# Altium schematic sheet MotorController.SchDoc
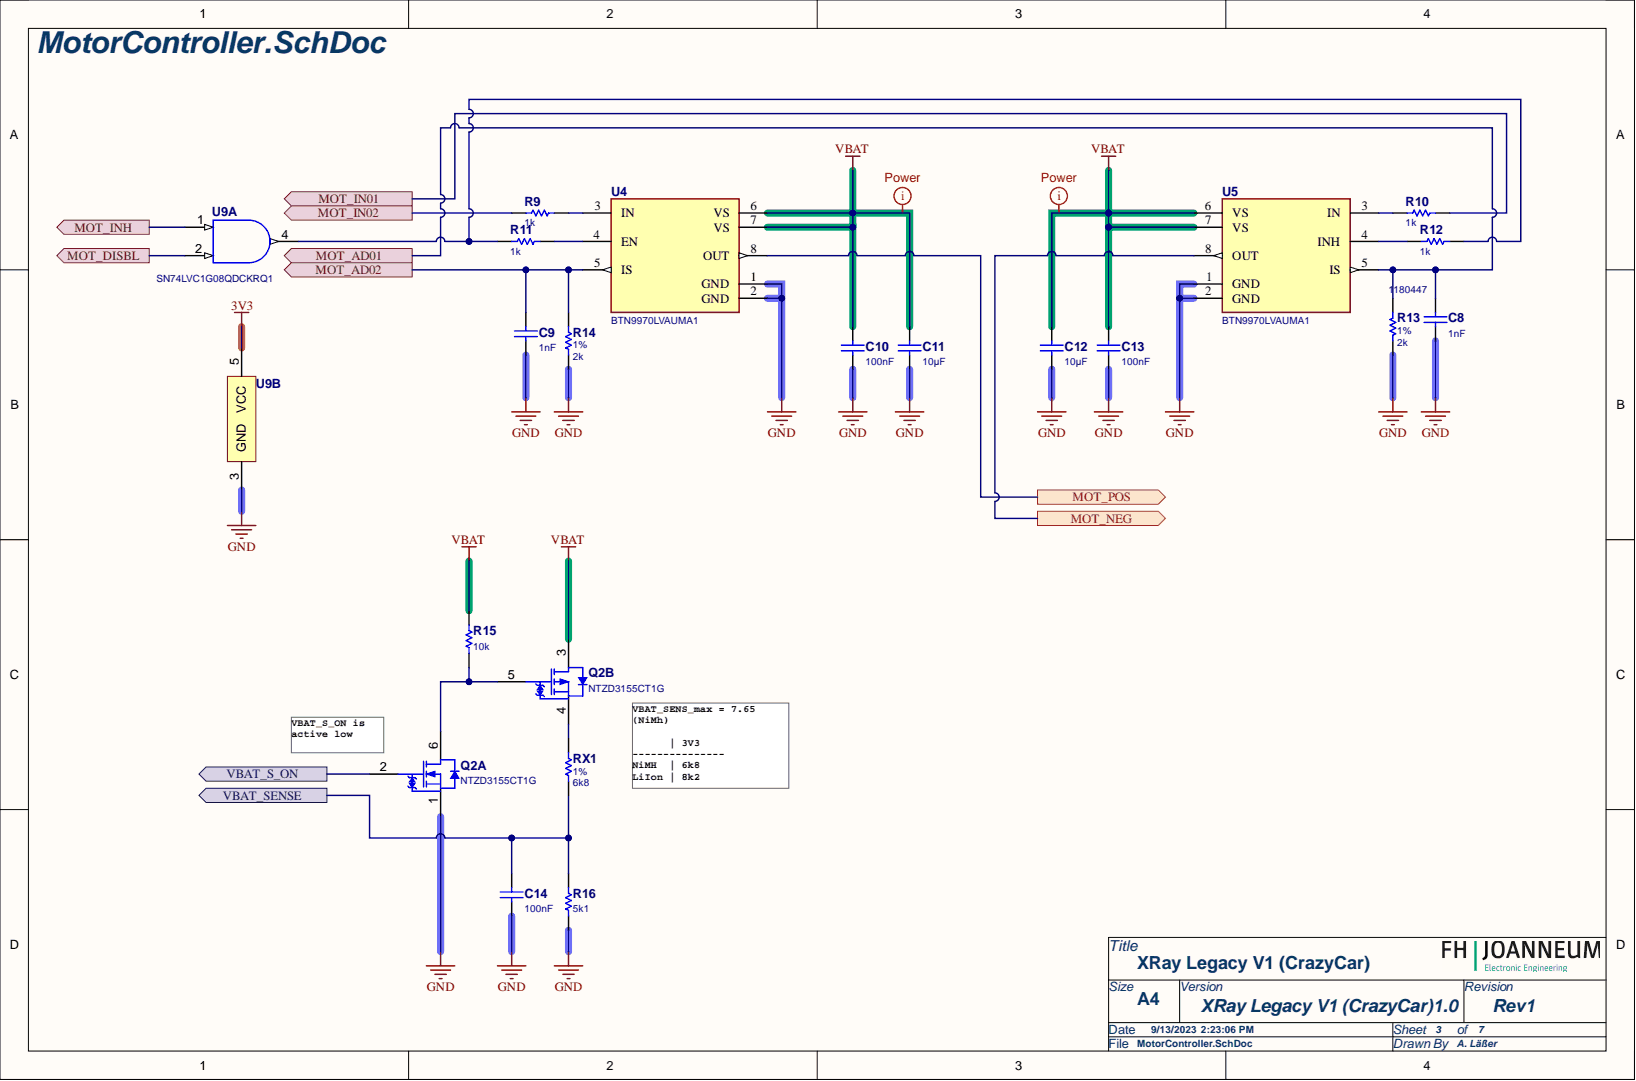
[diagram p0: MotorController.SchDoc]

## Parts
C8  1nF  [WCAP-CSGP_0805_L2W1.25H0.8_Reflow]
C9  1nF  [WCAP-CSGP_0805_L2W1.25H0.8_Reflow]
C10  100nF  [WCAP-CSGP_0805_L2W1.25H0.8_Reflow]
C11  10µF  [WCAP-CSGP_0805_L2W1.25H1.25]
C12  10µF  [WCAP-CSGP_0805_L2W1.25H1.25]
C13  100nF  [WCAP-CSGP_0805_L2W1.25H0.8_Reflow]
C14  100nF  [WCAP-CSGP_0805_L2W1.25H0.8_Reflow]
Q2  NTZD3155CT1G  [ONSC-SOT-563-6-463A-01_V]  (parts A,B)
R9  Resistance: 1k  [RESC2013X60X35ML10T20]  (hidden comment: RC0805FR-071KL)
R10  Resistance: 1k  [RESC2013X60X35ML10T20]  (hidden comment: RC0805FR-071KL)
R11  Resistance: 1k  [RESC2013X60X35ML10T20]  (hidden comment: RC0805FR-071KL)
R12  Resistance: 1k  [RESC2013X60X35ML10T20]  (hidden comment: RC0805FR-071KL)
R13  Resistance: 2k  Supplier Part Number 2: 1180447  Tolerance: 1%  [RESC2013X60X35ML10T20]  (hidden comment: RC0805FR-072K0L)
R14  Resistance: 2k  Tolerance: 1%  [RESC2013X60X35ML10T20]  (hidden comment: RC0805FR-072K0L)
R15  Resistance: 10k  [RESC2013X60X35ML10T20]  (hidden comment: RC0805FR-0710KL)
R16  Resistance: 5k1  [RESC2013X60X35ML10T20]  (hidden comment: RC0805FR-0710KL)
RX1  Resistance: 6k8  Tolerance: 1%  [RESC2013X60X35LL10T20]  (hidden comment: RC0805FR-136K8L)
U4  BTN9970LVAUMA1  [FP-PG-HSOF-7-MFG]
U5  BTN9970LVAUMA1  [FP-PG-HSOF-7-MFG]
U9  SN74LVC1G08QDCKRQ1  [DCK0005A_M]  (parts A,B)

## Ports
MOT_AD01, MOT_AD02, MOT_DISBL, MOT_IN01, MOT_IN02, MOT_INH, MOT_NEG, MOT_POS, VBAT_S_ON, VBAT_SENSE

## Nets
3V3: U9.5(VCC)
GND: C8.2 C9.2 C10.1 C11.2 C12.2 C13.1 C14.1 Q2.1 R13.2 R14.2 R16.2 U4.1(GND) U4.2(GND) U5.1(GND) U5.2(GND) U9.3(GND)
VBAT: C10.2 C11.1 C12.1 C13.2 Q2.3 R15.1 U4.6(VS) U4.7(VS) U5.6(VS) U5.7(VS)
MOT_AD01: C8.1 R13.1 U5.5(IS) ; port MOT_AD01
MOT_AD02: C9.1 R14.1 U4.5(IS) ; port MOT_AD02
MOT_DISBL: U9.2 ; port MOT_DISBL
MOT_IN01: R10.2 ; port MOT_IN01
MOT_IN02: R9.1 ; port MOT_IN02
MOT_INH: U9.1 ; port MOT_INH
MOT_NEG: U5.8(OUT) ; port MOT_NEG
MOT_POS: U4.8(OUT) ; port MOT_POS
VBAT_S_ON: Q2.2 ; port VBAT_S_ON
VBAT_SENSE: C14.2 R16.1 RX1.1 ; port VBAT_SENSE
NetQ2_4: Q2.4 RX1.2
NetQ2_5: Q2.5 Q2.6 R15.2
NetR9_2: R9.2 U4.3(IN)
NetR10_1: R10.1 U5.3(IN)
NetR11_1: R11.1 R12.2 U9.4
NetR11_2: R11.2 U4.4(EN)
NetR12_1: R12.1 U5.4(INH)

## Library data of the parts
C8 C9 = 885012207086: WCAP-CSGP Multilayer Ceramic Chip Capacitor, General Purpose, size 0805, X7R, 1nF, 50VDC; Manufacturer: Wurth Elektronik; Capacitance Tolerance: +/-10%; Case/Size Code: 0805; Dissipation Factor: 2.5%; Insulation Resistance: 10GΩ; Rated Voltage (DC): 50V; Technical Reference: X7R0805102K050DFCT10000; Capacitance: 1nF; Category: Multilayer Ceramic Chip Capacitors; Ceramic Type: X7R; Component Kind: Standard; ComponentLink1Description: Website Link; ComponentLink1URL: https://www.we-online.com/redexpert/article/885012207086?ad; ComponentLink2Description: Datasheet Link; ComponentLink2URL: https://www.we-online.com/redexpert/spec/885012207086?ad; Height: 0.8mm; Length: 2mm; Library Name: Altium_WCAP-CSGP (rev22e).IntLib; Manufacturer Part Number: 885012207086; Match Code: WCAP-CSGP; Mount: Surface Mount; Operating Temperature Max: 125°C; Operating Temperature Min: -55°C; Packaging: Tape and Reel; Width: 1.25mm
C10 C13 C14 = 885012207098: WCAP-CSGP Multilayer Ceramic Chip Capacitor, General Purpose, size 0805, X7R, 100nF, 50VDC; Manufacturer: Wurth Elektronik; Capacitance Tolerance: +/-10%; Case/Size Code: 0805; Dissipation Factor: 2.5%; Insulation Resistance: 5GΩ; Rated Voltage (DC): 50V; Technical Reference: X7R0805104K050DFCT10000; Capacitance: 100nF; Category: Multilayer Ceramic Chip Capacitors; Ceramic Type: X7R; Component Kind: Standard; ComponentLink1Description: Website Link; ComponentLink1URL: https://www.we-online.com/redexpert/article/885012207098?ad; ComponentLink2Description: Datasheet Link; ComponentLink2URL: https://www.we-online.com/redexpert/spec/885012207098?ad; Height: 0.8mm; Length: 2mm; Library Name: Altium_WCAP-CSGP (rev22e).IntLib; Manufacturer Part Number: 885012207098; Match Code: WCAP-CSGP; Mount: Surface Mount; Operating Temperature Max: 125°C; Operating Temperature Min: -55°C; Packaging: Tape and Reel; Width: 1.25mm
C11 C12 = 885012107014: WCAP-CSGP Multilayer Ceramic Chip Capacitor, General Purpose, size 0805, X5R, 10µF, 16VDC; Manufacturer: Wurth Elektronik; Capacitance Tolerance: +/-20%; Case/Size Code: 0805; Dissipation Factor: 10%; Insulation Resistance: 0.01GΩ; Rated Voltage (DC): 16V; Technical Reference: X5R0805106M016DFCT10000; Capacitance: 10µF; Category: Multilayer Ceramic Chip Capacitors; Ceramic Type: X5R; Component Kind: Standard; ComponentLink1Description: Website Link; ComponentLink1URL: https://www.we-online.com/redexpert/article/885012107014?ad; ComponentLink2Description: Datasheet Link; ComponentLink2URL: https://www.we-online.com/redexpert/spec/885012107014?ad; Height: 1.25mm; Length: 2mm; Library Name: Altium_WCAP-CSGP (rev22e).IntLib; Manufacturer Part Number: 885012107014; Match Code: WCAP-CSGP; Mount: Surface Mount; Operating Temperature Max: 85°C; Operating Temperature Min: -55°C; Packaging: Tape and Reel; Width: 1.25mm
Q2 = CMP-1058-00825-1: Small Signal MOSFET, Complementary 20 V, 540 mA / -430 mA, with ESD Protection, 6-Pin SOT-563, Pb-Free, Tape and Reel; Manufacturer: On Semiconductor; Configuration: Dual; Crss Typ (pF): 10; V(BR)DSS Min (V): 20; VGS Max (V): 6; VGS(th) Max (V): 1; Channel Polarity: Complementary; Component Kind: Standard; ComponentLink1Description: Manufacturer URL; ComponentLink1URL: http://www.onsemi.com/; ComponentLink2Description: Datasheet; ComponentLink2URL: http://www.onsemi.com/pub_link/Collateral/NTZD3155C-D.PDF; ComponentLink3Description: Package Specification; ComponentLink3URL: http://www.onsemi.cn/pub_link/Collateral/463A-01.PDF; DatasheetVersion: Rev. 3; Library Name: Altium Content Vault; Mounting Technology: Surface Mount; Package Type: SOT-563; PackageDescription: 6-Pin Small Outline Transistor, Body 1.6 x 1.2 mm, Pitch 0.5 mm; PackageReference: SOT-563-6-463A-01; PackageVersion: Rev. F, 04/2005; Packing: Tape and Reel; PartNumber: NTZD3155CT1G; RoHS: Pb-Free
R9-R12 = CMP-2100-03671-1: Chip Resistor, 1 KOhm, +/- 1%, 125 mW, -55 to 155 degC, 0805 (2012 Metric), RoHS, Tape and Reel; Manufacturer: Yageo; Additional Feature: LASER TRIMMABLE; Max Power Dissipation: 125mW; Package Height: 0.5mm; Package Length: 2mm; Package Width: 1.25mm; Rated Temperature: 70°C; Temperature Coefficient: 100ppm/degreesC; Voltage Rating: 150V; Case Code (Imperial): 0805; Case Code (Metric): 2012; Case/Package: 0805; Category: Chip SMD Resistors; Component Kind: Standard; ComponentLink1Description: https://datasheet.ciiva.com:443/pdfs/VipMasterIC/IC/YAGO/YAGO-S-A0008145938/YAGO-S-A0008145938-1.pdf?src-supplier=IHS+Markit; ComponentLink1URL: https://datasheet.ciiva.com:443/pdfs/VipMasterIC/IC/YAGO/YAGO-S-A0008145938/YAGO-S-A0008145938-1.pdf?src-supplier=IHS+Markit; ComponentLink2Description: https://datasheet.ciiva.com/7941/1686128-7941719.pdf?src-supplier=Element14; ComponentLink2URL: https://datasheet.ciiva.com/7941/1686128-7941719.pdf?src-supplier=Element14; ComponentLink3Description: https://datasheet.ciiva.com/46385/444164-46385020.pdf?src-supplier=Element14; ComponentLink3URL: https://datasheet.ciiva.com/46385/444164-46385020.pdf?src-supplier=Element14; ComponentLink4Description: https://datasheet.ciiva.com/2456/pyu-r-int-thick-7-2456976.pdf?src-supplier=Digi-Key; ComponentLink4URL: https://datasheet.ciiva.com/2456/pyu-r-int-thick-7-2456976.pdf?src-supplier=Digi-Key; ComponentLink5Description: https://datasheet.ciiva.com/2456/pyu-r-int-thick-7-2456977.pdf?src-supplier=Digi-Key; ComponentLink5URL: https://datasheet.ciiva.com/2456/pyu-r-int-thick-7-2456977.pdf?src-supplier=Digi-Key; ComponentLink6Description: https://datasheet.ciiva.com/2456/pyu-r-int-thick-7-2456975.pdf?src-supplier=Digi-Key; ComponentLink6URL: https://datasheet.ciiva.com/2456/pyu-r-int-thick-7-2456975.pdf?src-supplier=Digi-Key; ComponentLink7Description: https://datasheet.ciiva.com/16850/rc0805-16850409.pdf?src-supplier=Future+Electronics; ComponentLink7URL: https://datasheet.ciiva.com/16850/rc0805-16850409.pdf?src-supplier=Future+Electronics; Composition: ThickFilm; Construction: Chip; Datasheet URL: http://www.yageo.com/NewPortal/yageodocoutput?fileName=/pdf/R-Chip/PYu-RC_Group_51_RoHS_L_5.pdf; Datasheet Version: Rev. 5, 10/2016; Depth: 1.25mm; Height: 0.024inch; JESD-609 Code: e3; Lead Free: LeadFree; Length: 0.079inch; Library Name: Altium Content Vault; Manufacturer Part Number: RC0805FR-071KL; Manufacturer URL: http://www.yageo.com/; Max Operating Temperature: 155degC; Military Standard: Not; Min Operating Temperature: -55degC; Mount: Surface Mount; Number of Pins: 2; Number of Terminations: 2; Package Description: 2-Pin Surface Mounted Device, Body 2 x 1.25 mm; Package Quantity: 1; Package Reference: 0805; Package Shape: Rectangular; Package Style: SMTMeter; Packaging: TapeandReel; Power Rating: 125mW; Pricing 1: 5000=0.00500 (USD); Rated Power Dissipation (P): 125mW; REACH SVHC: Unknown; Resistor Type: FIXED RESISTOR; RoHS: Yes; RoHS Compliant: Yes; RoHSCompliant: true; Size Code: 0805; Stock 1: 490000; Supplier 1: RSComponents; Supplier Part Number 1: 6183583; Technology: METAL GLAZE/THICK FILM; Terminal Finish: Nickel; Termination: SMD/SMT; Tolerance: 1%; Voltage Rating (DC): 150V; Width: 0.049inch; Working Voltage: 150V
R13 R14 = CMP-2001-01049-1: Fixed Resistor, Metal Glaze/thick Film, 0.125W, 2000ohm, 150V, 1% +/-Tol, 100ppm/Cel, Surface Mount, 0805; Manufacturer: Yageo; Manufacturer Part Number: RC0805FR-072K43L; Additional Feature: LASER TRIMMABLE; Package Height: 0.5mm; Package Length: 2mm; Package Width: 1.25mm; Rated Power Dissipation (P): 125mW; Rated Temperature: 70°C; Temperature Coefficient: 100ppm/°C; Category: Chip SMD Resistors; Component Kind: Standard; ComponentLink1Description: https://datasheet.ciiva.com:443/pdfs/VipMasterIC/IC/YAGO/YAGO-S-A0008145938/YAGO-S-A0008145938-1.pdf?src-supplier=IHS+Markit; ComponentLink1URL: https://datasheet.ciiva.com:443/pdfs/VipMasterIC/IC/YAGO/YAGO-S-A0008145938/YAGO-S-A0008145938-1.pdf?src-supplier=IHS+Markit; ComponentLink2Description: https://datasheet.ciiva.com/12667/rc0805-12667358.pdf?src-supplier=Mouser; ComponentLink2URL: https://datasheet.ciiva.com/12667/rc0805-12667358.pdf?src-supplier=Mouser; ComponentLink3Description: https://datasheet.ciiva.com/17054/rc0805-17054853.pdf?src-supplier=Future+Electronics; ComponentLink3URL: https://datasheet.ciiva.com/17054/rc0805-17054853.pdf?src-supplier=Future+Electronics; Construction: Chip; JESD-609 Code: e3; Library Name: Altium Content Vault; Max Operating Temperature: 155°C; Min Operating Temperature: -55°C; Mount: Surface Mount; Number of Pins: 2; Package Shape: Rectangular; Package Style: SMTMeter; Packaging: Tape and Reel; Part Number: RC0805FR-072K43L; Pricing 1: 5000=0.0026, 25000=0.0024, 125000=0.0023, 375000=0.0022, 1000000=0.002 (USD); Reach SVHC Compliant: Yes; Resistor Type: FIXED RESISTOR; RoHS: Yes; RoHS Compliant: Yes; Size Code: 0805; Stock 1: 20000; Supplier 1: Future Electronics; Supplier Part Number 1: 1019437; Technology: METAL GLAZE/THICK FILM; Terminal Finish: Nickel; Termination: Wraparound; Working Voltage: 150V
  R13: ComponentLink4Description: https://datasheet.ciiva.com/11819/0900766b8106beab-11819830.pdf?src-supplier=Rs; ComponentLink4URL: https://datasheet.ciiva.com/11819/0900766b8106beab-11819830.pdf?src-supplier=Rs; ComponentLink5Description: https://datasheet.ciiva.com/46395/444164-46395128.pdf?src-supplier=Element14; ComponentLink5URL: https://datasheet.ciiva.com/46395/444164-46395128.pdf?src-supplier=Element14; ComponentLink6Description: https://datasheet.ciiva.com/2457/pyu-r-int-thick-7-2457027.pdf?src-supplier=Digi-Key; ComponentLink6URL: https://datasheet.ciiva.com/2457/pyu-r-int-thick-7-2457027.pdf?src-supplier=Digi-Key; ComponentLink7Description: https://datasheet.ciiva.com/2457/pyu-r-int-thick-7-2457028.pdf?src-supplier=Digi-Key; ComponentLink7URL: https://datasheet.ciiva.com/2457/pyu-r-int-thick-7-2457028.pdf?src-supplier=Digi-Key; ComponentLink8Description: https://datasheet.ciiva.com/2457/pyu-r-int-thick-7-2457026.pdf?src-supplier=Digi-Key; ComponentLink8URL: https://datasheet.ciiva.com/2457/pyu-r-int-thick-7-2457026.pdf?src-supplier=Digi-Key; ComponentLink9Description: https://datasheet.ciiva.com/12667/rc0805-12667946.pdf?src-supplier=Mouser; ComponentLink9URL: https://datasheet.ciiva.com/12667/rc0805-12667946.pdf?src-supplier=Mouser; Pricing 2: 1=0.1, 20=0.0658, 100=0.0475, 500=0.0292, 2500=0.0108 (USD); Stock 2: 5000; Supplier 2: Future Electronics
R15 R16 = CMP-1659-00013-4: Chip Resistor, 10 KOhm, +/- 1%, 0.125 W, -55 to 155 degC, 0805 (2012 Metric), RoHS, Tape and Reel; Manufacturer: Yageo; Additional Feature: LASER TRIMMABLE; Package Height: 0.5mm; Package Length: 2mm; Package Width: 1.25mm; Rated Power Dissipation (P): 125mW; Rated Temperature: 70°C; Temperature Coefficient: 100ppm/°C; Voltage Rating: 150 V; Working Voltage: 150V; Category: Chip SMD Resistors; Component Kind: Standard; ComponentLink1Description: Manufacturer URL; ComponentLink1URL: http://www.yageo.com/; ComponentLink2Description: Datasheet; ComponentLink2URL: http://www.yageo.com/documents/recent/PYu-RC_Group_51_RoHS_L_04.pdf; ComponentLink3Description: https://datasheet.ciiva.com:443/pdfs/VipMasterIC/IC/YAGO/YAGO-S-A0008145938/YAGO-S-A0008145938-1.pdf?src-supplier=IHS+Markit; ComponentLink3URL: https://datasheet.ciiva.com:443/pdfs/VipMasterIC/IC/YAGO/YAGO-S-A0008145938/YAGO-S-A0008145938-1.pdf?src-supplier=IHS+Markit; ComponentLink4Description: https://datasheet.ciiva.com/11808/0900766b8106beab-11808151.pdf?src-supplier=RSComponents; ComponentLink4URL: https://datasheet.ciiva.com/11808/0900766b8106beab-11808151.pdf?src-supplier=RSComponents; ComponentLink5Description: https://datasheet.ciiva.com/46447/444164-46447563.pdf?src-supplier=Element14; ComponentLink5URL: https://datasheet.ciiva.com/46447/444164-46447563.pdf?src-supplier=Element14; ComponentLink6Description: https://datasheet.ciiva.com/2456/pyu-r-int-thick-7-2456973.pdf?src-supplier=Digi-Key; ComponentLink6URL: https://datasheet.ciiva.com/2456/pyu-r-int-thick-7-2456973.pdf?src-supplier=Digi-Key; ComponentLink7Description: https://datasheet.ciiva.com/2456/pyu-r-int-thick-7-2456974.pdf?src-supplier=Digi-Key; ComponentLink7URL: https://datasheet.ciiva.com/2456/pyu-r-int-thick-7-2456974.pdf?src-supplier=Digi-Key; ComponentLink8Description: https://datasheet.ciiva.com/2456/pyu-r-int-thick-7-2456972.pdf?src-supplier=Digi-Key; ComponentLink8URL: https://datasheet.ciiva.com/2456/pyu-r-int-thick-7-2456972.pdf?src-supplier=Digi-Key; ComponentLink9Description: https://datasheet.ciiva.com/12669/rc0805-12669688.pdf?src-supplier=Mouser; ComponentLink9URL: https://datasheet.ciiva.com/12669/rc0805-12669688.pdf?src-supplier=Mouser; ComponentLink10Description: https://datasheet.ciiva.com/16747/rc0805-16747087.pdf?src-supplier=Future+Electronics; ComponentLink10URL: https://datasheet.ciiva.com/16747/rc0805-16747087.pdf?src-supplier=Future+Electronics; Construction: Chip; DatasheetVersion: Rev. 4, 02/2016; Height: 0.5 mm; JESD-609 Code: e3; Length: 2 mm; Library Name: Altium Content Vault; Manufacturer Part Number: RC0805FR-0710KL; Max Operating Temperature: 155 degC; Min Operating Temperature: -55 degC; Mount: Surface Mount; Mounting Technology: Surface Mount; Number of Pins: 2; Package Quantity: 5000; Package Shape: Rectangular; Package Style: SMTMeter; PackageDescription: 2-Pin Surface Mounted Device, Body 2 x 1.25 mm; PackageReference: 0805; Packaging: Tape and Reel; Pins: 2; Pricing 1: 5000=0.00700 (USD); REACH SVHC: No SVHC; Resistor Type: FIXED RESISTOR; RoHS: Yes; RoHS Compliant: Yes; RoHSCompliant: true; Size Code: 0805; Stock 1: 435000; Supplier 1: RSComponents; Supplier Part Number 1: 6185163; Technology: METAL GLAZE/THICK FILM; Terminal Finish: Nickel; Termination: Wraparound; Tolerance: 1%
RX1 = CMP-2001-04567-1: Fixed Resistor, Metal Glaze/thick Film, 0.125W, 6800ohm, 150V, 1% +/-Tol, 100ppm/Cel, Surface Mount, 0805; Manufacturer: Yageo; Additional Feature: LASER TRIMMABLE; Package Height: 0.5mm; Package Length: 2mm; Package Width: 1.25mm; Rated Power Dissipation (P): 125mW; Rated Temperature: 70°C; Temperature Coefficient: 100ppm/°C; Category: Chip SMD Resistors; Component Kind: Standard; ComponentLink1Description: https://datasheet.ciiva.com:443/pdfs/VipMasterIC/IC/YAGO/YAGO-S-A0008145938/YAGO-S-A0008145938-1.pdf?src-supplier=IHS+Markit; ComponentLink1URL: https://datasheet.ciiva.com:443/pdfs/VipMasterIC/IC/YAGO/YAGO-S-A0008145938/YAGO-S-A0008145938-1.pdf?src-supplier=IHS+Markit; ComponentLink2Description: http://www.mouser.com/ds/2/447/Yageo_08112016_RC0805-968729.pdf?src-supplier=Mouser; ComponentLink2URL: http://www.mouser.com/ds/2/447/Yageo_08112016_RC0805-968729.pdf?src-supplier=Mouser; ComponentLink3Description: http://www.yageo.com/documents/recent/PYu-RC_Group_51_RoHS_L_2.pdf?src-supplier=Avnet; ComponentLink3URL: http://www.yageo.com/documents/recent/PYu-RC_Group_51_RoHS_L_2.pdf?src-supplier=Avnet; Construction: Chip; JESD-609 Code: e3; Library Name: Altium Content Vault; Manufacturer Part Number: RC0805FR-136K8L; Max Operating Temperature: 155°C; Min Operating Temperature: -55°C; Mount: Surface Mount; Number of Pins: 2; Package Shape: Rectangular; Package Style: SMTMeter; Packaging: Tape and Reel; Part Number: RC0805FR-136K8L; Pricing 1: 1=0.1, 10=0.024, 50=0.0152, 100=0.0127, 500=0.00866, 1000=0.00748, 5000=0.00548, 10000=0.00486 (USD); Reach SVHC Compliant: Yes; Resistor Type: FIXED RESISTOR; RoHS: Yes; RoHS Compliant: Yes; Size Code: 0805; Stock 1: 31895; Supplier 1: Digi-Key; Supplier Part Number 1: 13-RC0805FR-136K8LCT-ND; Technology: METAL GLAZE/THICK FILM; Terminal Finish: Nickel; Termination: Wraparound; Working Voltage: 150V
U4 U5 = CMP-32854-000022-1: DC_MOTOR_CONTROL PG-HSOF-7; Component Kind: Standard; Library Name: Altium Content Vault
U9 = CMP-0859-00272-3: Automotive Catalog Single 2-Input Positive-AND Gate, DCK0005A, LARGE T&R; Generic Part Number: SN74LVC1G08-Q1; Manufacturer: Texas Instruments; 3-State Output: No; Bits(#): 1; F @ Nom Voltage(Max)(Mhz): 150; Gate Type: AND; ICC @ Nom Voltage(Max)(mA): 0.01; Input Type: CMOS/TTL; Logic: True; Output Drive (IOL/IOH)(Max)(mA): 32/-32; Output Type: CMOS; Technology Family: LVC; tpd @ Nom Voltage(Max)(ns): 8,5.5,4.5,4; VCC(Max)(V): 5.5; VCC(Min)(V): 1.65; Voltage(Nom)(V): 1.8,2.5,3.3,5; Component Kind: Standard; DatasheetVersion: SCES556F; Library Name: Altium Content Vault; Operating Temperature Range(C): -40 to 125,-40 to 85; PackageReference: DCK0005A; Rating: Automotive; Schmitt Trigger: No; Sub-Family: AND Gate
